# Altium schematic sheet Sheet2.SchDoc
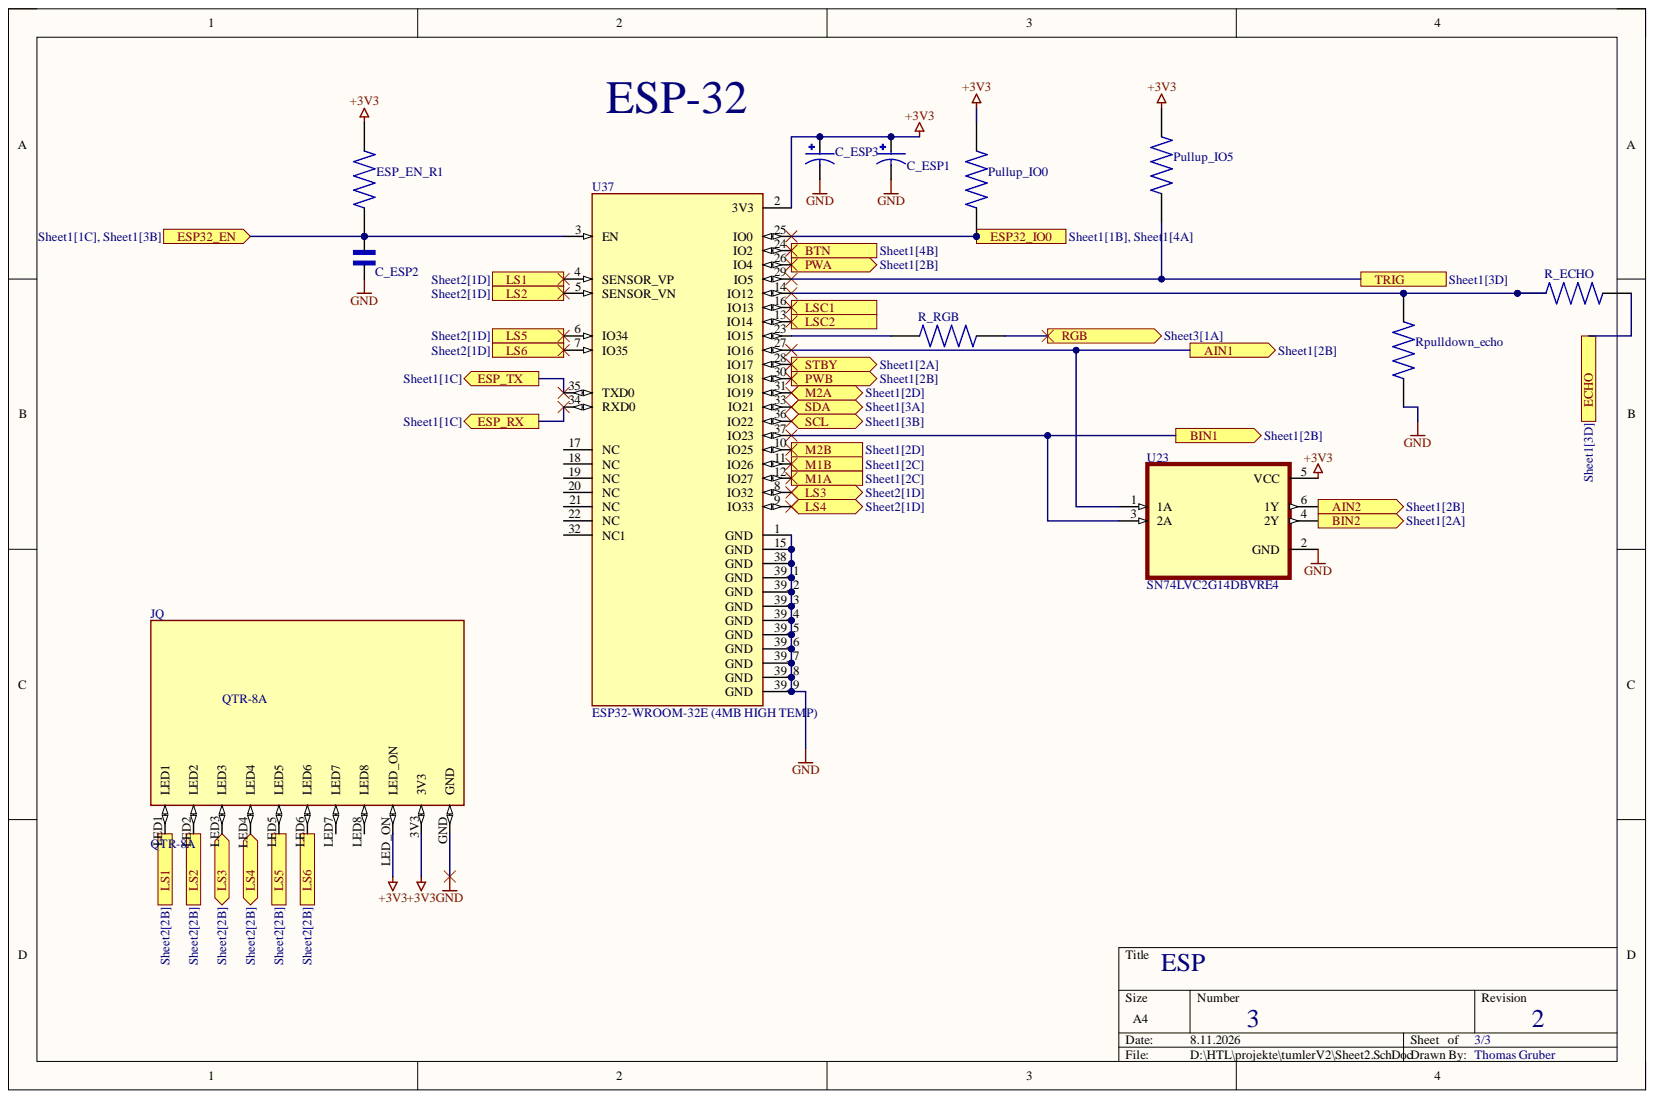
[diagram p0: Sheet2.SchDoc]

## Parts
C_ESP1  EEE1HA010SR  [CAP_EEE1HA010SR]  (value not drawn)
C_ESP2  VJ0805A681KXCAP  [CAPC2012X145N]  (value not drawn)
C_ESP3  EEEFK1E100R  [CAP_EEEFK1E100R]  (value not drawn)
ESP_EN_R1  0805W8F1002T5E  [RESC2012X65N]  (value not drawn)
JQ  QTR-8A  [QTR-8A]
Pullup_IO0  0805W8F1002T5E  [RESC2012X65N]  (value not drawn)
Pullup_IO5  0805W8F1002T5E  [RESC2012X65N]  (value not drawn)
R_ECHO  CRCW0805470RFKEAC  [RESC2012X60N]  (value not drawn)
R_RGB  CRCW0805470RFKEAC  [RESC2012X60N]  (value not drawn)
Rpulldown_echo  0805W8F1002T5E  [RESC2012X65N]  (value not drawn)
U23  SN74LVC2G14DBVRE4  [SOT95P280X145-6N]
U37  ESP32-WROOM-32E (4MB HIGH TEMP)  [XCVR_ESP32-WROOM-32E_(4MB_HIGH_TEMP)]

## Ports
AIN1, AIN2, BIN1, BIN2, BTN, ECHO, ESP32_EN, ESP32_IO0, ESP_RX, ESP_TX, LS1, LS2, LS3, LS4, LS5, LS6, LSC1, LSC2, M1A, M1B, M2A, M2B, PWA, PWB, RGB, SCL, SDA, STBY, TRIG

## Nets
+3V3: C_ESP1.1 C_ESP3.1 ESP_EN_R1.2 JQ.3V3 JQ.LED_ON Pullup_IO0.2 Pullup_IO5.2 U23.5(VCC) U37.2(3V3)
GND: C_ESP1.2 C_ESP2.1 C_ESP3.2 JQ.GND Rpulldown_echo.1 U23.2(GND) U37.1(GND) U37.15(GND) U37.38(GND) U37.39_1(GND) U37.39_2(GND) U37.39_3(GND) U37.39_4(GND) U37.39_5(GND) U37.39_6(GND) U37.39_7(GND) U37.39_8(GND) U37.39_9(GND)
AIN1: U23.1(1A) U37.27(IO16) ; port AIN1
AIN2: U23.6(1Y) ; port AIN2
BIN1: U23.3(2A) U37.37(IO23) ; port BIN1
BIN2: U23.4(2Y) ; port BIN2
BTN: U37.24(IO2) ; port BTN
ECHO: R_ECHO.2 ; port ECHO
ESP32_EN: C_ESP2.2 ESP_EN_R1.1 U37.3(EN) ; port ESP32_EN
ESP32_IO0: Pullup_IO0.1 U37.25(IO0) ; port ESP32_IO0
ESP_RX: U37.34(RXD0) ; port ESP_RX
ESP_TX: U37.35(TXD0) ; port ESP_TX
LS1: JQ.LED1 U37.4(SENSOR_VP) ; port LS1
LS2: JQ.LED2 U37.5(SENSOR_VN) ; port LS2
LS3: JQ.LED3 U37.8(IO32) ; port LS3
LS4: JQ.LED4 U37.9(IO33) ; port LS4
LS5: JQ.LED5 U37.6(IO34) ; port LS5
LS6: JQ.LED6 U37.7(IO35) ; port LS6
LSC1: U37.16(IO13) ; port LSC1
LSC2: U37.13(IO14) ; port LSC2
M1A: U37.12(IO27) ; port M1A
M1B: U37.11(IO26) ; port M1B
M2A: U37.31(IO19) ; port M2A
M2B: U37.10(IO25) ; port M2B
PWA: U37.26(IO4) ; port PWA
PWB: U37.30(IO18) ; port PWB
RGB: R_RGB.2 ; port RGB
SCL: U37.36(IO22) ; port SCL
SDA: U37.33(IO21) ; port SDA
STBY: U37.28(IO17) ; port STBY
TRIG: Pullup_IO5.1 U37.29(IO5) ; port TRIG
NetR_ECHO_1: R_ECHO.1 Rpulldown_echo.2 U37.14(IO12)
NetR_RGB_1: R_RGB.1 U37.23(IO15)

Not connected: JQ LED7 LED8; U37 17(NC) 18(NC) 19(NC) 20(NC) 21(NC) 22(NC) 32(NC1)

## Library data of the parts
C_ESP1 = EEE1HA010SR: Aluminum Electrolytic Capacitor, 2000h 4 (Dia) x 5.4mm, 1uF 50 VDC, SV Series | Panasonic Electronic Components EEE1HA010SR; Value: 1µ; Check_prices: https://www.snapeda.com/parts/EEE1HA010SR/Panasonic/view-part/?ref=eda; Component Kind: Standard; MF: Panasonic; MP: EEE1HA010SR; Package: RADIAL-2 Panasonic; SnapEDA_Link: https://www.snapeda.com/parts/EEE1HA010SR/Panasonic/view-part/?ref=snap
C_ESP2 = VJ0805A681KXCAP: CAP CER 100nF 200V C0G/NP0 0805; MF: Vishay Vitramon; Value: 100n; Check_prices: https://www.snapeda.com/parts/VJ0805A681KXCAP/Vishay/view-part/?ref=eda; Component Kind: Standard; Library Name: VJ0805A681KXCAP.IntLib; MP: VJ0805A681KXCAP; Package: 2012 Vishay Vitramon; SnapEDA_Link: https://www.snapeda.com/parts/VJ0805A681KXCAP/Vishay/view-part/?ref=snap
C_ESP3 = EEEFK1E100R: 10ÂµF 25V Aluminum Electrolytic Capacitors Radial, Can - SMD 2000 Hrs @ 105Â°C; MF: Panasonic; Value: 10µ; Check_prices: https://www.snapeda.com/parts/EEEFK1E100R/Panasonic/view-part/5793802/?ref=eda; Component Kind: Standard; Digi-Key_Part_Number: PCE3795CT-ND; MP: EEEFK1E100R; Package: RADIAL-2 Panasonic; SnapEDA_Link: https://www.snapeda.com/parts/EEEFK1E100R/Panasonic/view-part/5793802/?ref=snap
ESP_EN_R1 Pullup_IO0 Pullup_IO5 Rpulldown_echo = 0805W8F1002T5E: 10K Ohm 1/8W Thick Film Resistor 0805 Chip - Surface Mount; MF: Uni-Royal; Check_prices: https://www.snapeda.com/parts/0805W8F1002T5E/Uni-Royal/view-part/?ref=eda; Component Kind: Standard; MP: 0805W8F1002T5E; Package: 0805-2 ROYAL; SnapEDA_Link: https://www.snapeda.com/parts/0805W8F1002T5E/Uni-Royal/view-part/?ref=snap; Value: 10k
JQ = QTR-8A: QRE1113GR series Phototransistor Sensor Evaluation Board; MF: Pololu; Check_prices: https://www.snapeda.com/parts/QTR-8A/Pololu/view-part/?ref=eda; Component Kind: Standard; Library Name: QTR-8A.SchLib; MP: QTR-8A; Package: None; SnapEDA_Link: https://www.snapeda.com/parts/QTR-8A/Pololu/view-part/?ref=snap
R_ECHO R_RGB = CRCW0805470RFKEAC: 470 Ohms Â±1% 0.125W, 1/8W Chip Resistor 0805 (2012 Metric) - Thick Film; MF: Vishay; Value: 470; Check_prices: https://www.snapeda.com/parts/CRCW0805470RFKEAC/Vishay/view-part/?ref=eda; Component Kind: Standard; MP: CRCW0805470RFKEAC; Package: 2012 Vishay; SnapEDA_Link: https://www.snapeda.com/parts/CRCW0805470RFKEAC/Vishay/view-part/?ref=snap
U23 = SN74LVC2G14DBVRE4: Dual Schmitt-Trigger Inverter 6-SOT-23 -40 to 125; MF: Texas Instruments; Availability: In Stock; Check_prices: https://www.snapeda.com/parts/SN74LVC2G14DBVRE4/Texas+Instruments/view-part/?ref=eda; Component Kind: Standard; MP: SN74LVC2G14DBVRE4; Package: SOT-23-6 Texas Instruments; Price: None; Purchase-URL: https://pricing.snapeda.com/search/part/SN74LVC2G14DBVRE4/?ref=eda; SnapEDA_Link: https://www.snapeda.com/parts/SN74LVC2G14DBVRE4/Texas+Instruments/view-part/?ref=snap; Supplier 1: Mouser; Supplier Part Number 1: 595-SN74LVC2G14DBVE4
U37 = ESP32-WROOM-32E (4MB HIGH TEMP): ESP32-WROOM-32E 4N; Database Table Name: components; MF: Espressif Systems; Availability: In Stock; Check_prices: https://www.snapeda.com/parts/ESP32-WROOM-32E%20(4MB%20HIGH%20TEMP)/Espressif+Systems/view-part/?ref=eda; Component Kind: Standard; ID: ESP32-WROOM-32E (4MB HIGH TEMP); MP: ESP32-WROOM-32E (4MB HIGH TEMP); Package: SMD-44 Espressif Systems; Price: None; Purchase-URL: https://pricing.snapeda.com/search/part/ESP32-WROOM-32E (4MB HIGH TEMP)/?ref=eda; SnapEDA_Link: https://www.snapeda.com/parts/ESP32-WROOM-32E%20(4MB%20HIGH%20TEMP)/Espressif+Systems/view-part/?ref=snap; Supplier 1: Digikey; Supplier Part Number 1: 1904-ESP32-WROOM-32E(M113EH3200PH3Q0)TR-ND; Library Name: Snapmagic.DbLib
